# Altium schematic sheet PROGRAMMER.SchDoc
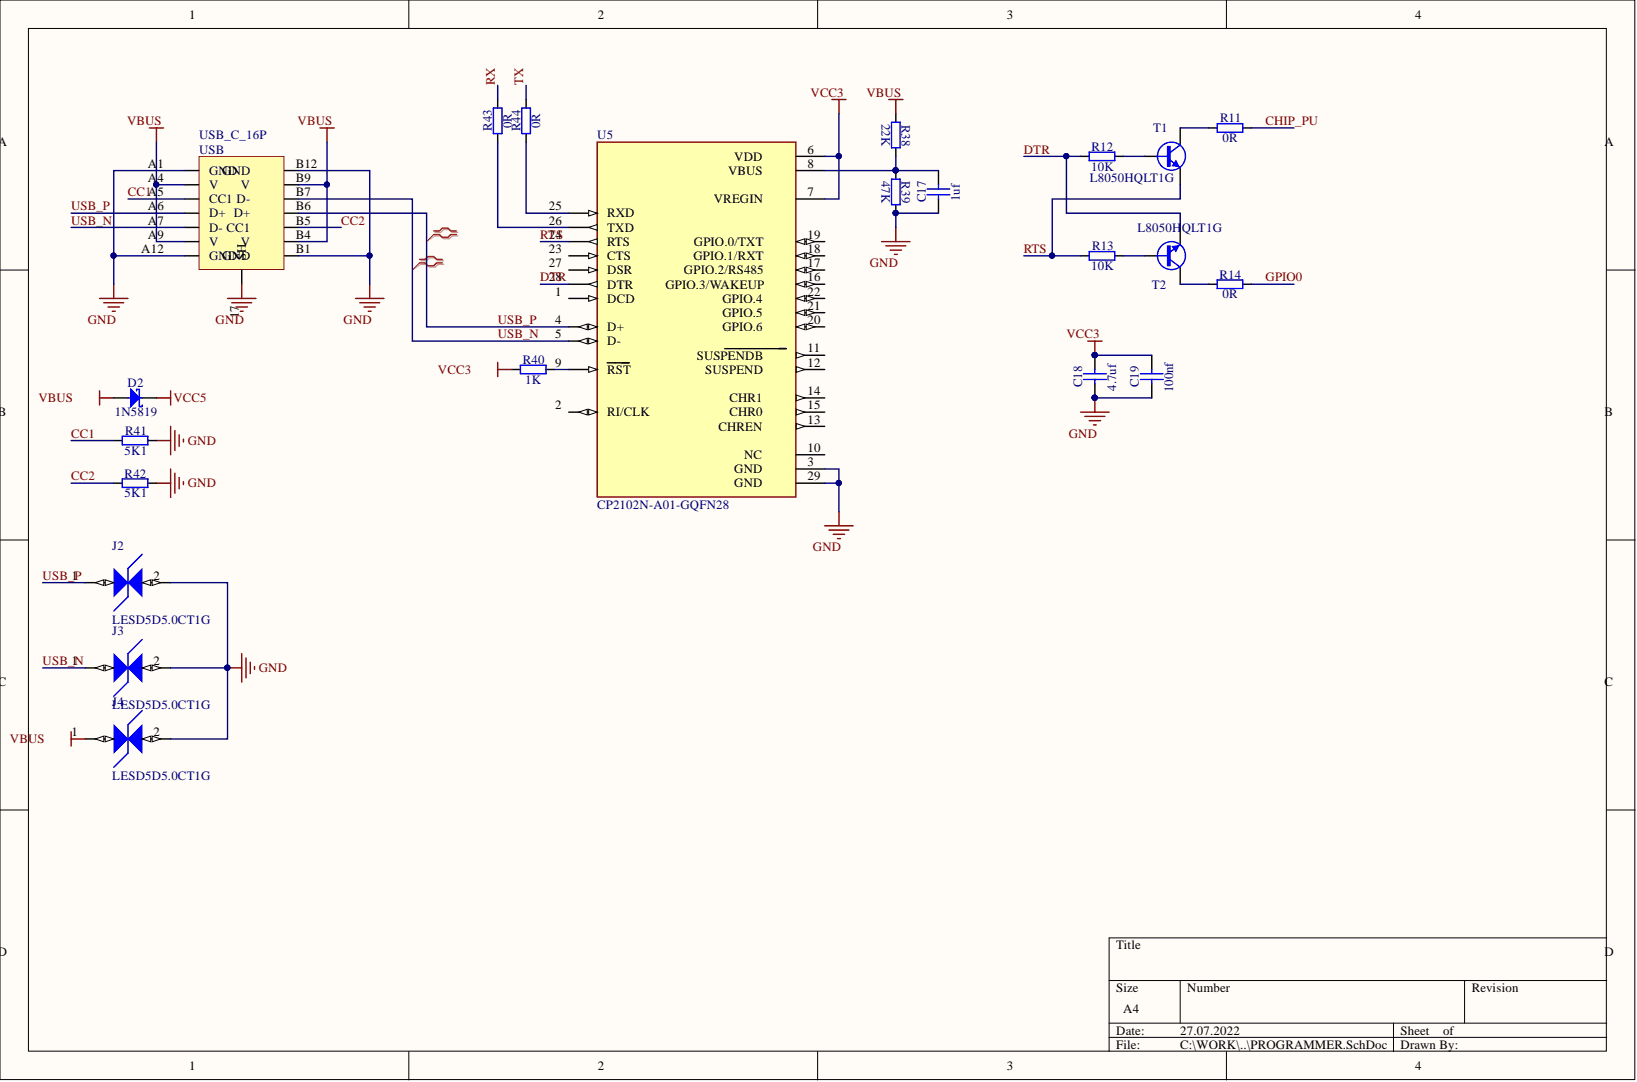
[diagram p0: PROGRAMMER.SchDoc]

## Parts
C17  1uf  [CAP_0603]
C18  4.7uf  [CAP_0805]
C19  100nf  [CAP_0603]
D2  1N5819  [SOD2713X115N]
J2  LESD5D5.0CT1G  [LESD5D5.0CT1G]
J3  LESD5D5.0CT1G  [LESD5D5.0CT1G]
J4  LESD5D5.0CT1G  [LESD5D5.0CT1G]
R11  0R  [RES_0603]
R12  10K  [RES_0603]
R13  10K  [RES_0603]
R14  0R  [RES_0603]
R38  22K  [RES_0603]
R39  47K  [RES_0603]
R40  1K  [RES_0603]
R41  5K1  [RES_0603]
R42  5K1  [RES_0603]
R43  0R  [RES_0603]
R44  0R  [RES_0603]
T1  L8050HQLT1G  [SOT95P240X110-3N]
T2  L8050HQLT1G  [SOT95P240X110-3N]
U5  CP2102N-A01-GQFN28  [QFN50P500X500X80-29N]
USB  USB_C_16P  [MC-TYF-J35-1635B]

## Nets
GND: C17.1 C18.1 C19.1 J2.2 J3.2 J4.2 R39.2 R41.2 R42.2 U5.3 U5.29 USB.17(SH) USB.A1(GND) USB.A12(GND) USB.B1 USB.B12
VBUS: D2.2 J4.1 R38.1 USB.A4(V) USB.A9(V) USB.B4 USB.B9
VCC3: C18.2 C19.2 R40.1 U5.6 U5.7
VCC5: D2.1
CC1: R41.1 USB.A5
CC2: R42.1 USB.B5
CHIP_PU: R11.2
DTR: R12.1 T2.2 U5.28(DTR)
GPIO0: R14.2
RTS: R13.1 T1.2 U5.24(RTS)
RX: R43.2
TX: R44.2
USB_N: J3.1 U5.5(D-) USB.A7(D-_CC1) USB.B7
USB_P: J2.1 U5.4(D+) USB.A6(D+) USB.B6
NetC17_2: C17.2 R38.2 R39.1 U5.8
NetR11_1: R11.1 T1.3
NetR12_2: R12.2 T1.1
NetR13_2: R13.2 T2.1
NetR14_1: R14.1 T2.3
NetR40_2: R40.2 U5.9(RST)
NetR43_1: R43.1 U5.26(TXD)
NetR44_1: R44.1 U5.25(RXD)

Not connected: U5 1(DCD) 2(RI/CLK) 10 11 12 13 14 15 16 17 18 19 20 21 22 23(CTS) 27(DSR)

## Library data of the parts
C17 = C0603_1UF: Non-polarised capacitor; Database Table Name: CAP; Voltage: 16V; Код: 40; Case: 0603; Component Kind: Standard; Part Number: C0603_1UF; Pin Count: 2; Value: 1uf; Library Name: MIPS_COMPONENTS_LIB.DbLib
C18 = C0805_4UF7: Non-polarised capacitor; Database Table Name: CAP; GoodsBriefName: RC_C_0805_4UF7_CC0805KKX5R7BB475_1PCS; GoodsID: 59207; Voltage: 16V; Код: 7; Case: 0805; Component Kind: Standard; Part Number: C0805_4UF7; Pin Count: 2; Value: 4.7uf; Library Name: MIPS_COMPONENTS_LIB.DbLib
C19 = C0603_100NF: Non-polarised capacitor; Database Table Name: CAP; GoodsBriefName: RC_C_0603_100NF_1PCS; GoodsID: 47802; Voltage: 50V; Код: 2; Case: 0603; Component Kind: Standard; Part Number: C0603_100NF; Pin Count: 2; Value: 100nf; Library Name: MIPS_COMPONENTS_LIB.DbLib
D2 = D_5819_SOD323: Schottky Diode; Database Table Name: D; GoodsBriefName: RC_D_1N5819_SOD323; GoodsID: 52854; Код: 5; Case: sod-323; Component Kind: Standard; Part Number: D_5819_SOD323; Pin Count: 2; Value: 1N5819; Library Name: MIPS_COMPONENTS_LIB.DbLib
J2-J4 = D_LESD5D5.0CT1G: Transient Voltage Suppressors for ESD Protection; Database Table Name: D; MF: Leshan Radio Co.; Код: 12; Availability: Not in stock; Case: SOD523; Check_prices: https://www.snapeda.com/parts/LESD5D5.0CT1G/Leshan%20Radio%20Co./view-part/4550964/?ref=eda; Component Kind: Standard; MP: LESD5D5.0CT1G; Package: None; Part Number: D_LESD5D5.0CT1G; Pin Count: 2; Price: None; SnapEDA_Link: https://www.snapeda.com/parts/LESD5D5.0CT1G/Leshan%20Radio%20Co./view-part/4550964/?ref=snap; Value: LESD5D5.0CT1G; Library Name: MIPS_COMPONENTS_LIB.DbLib
R11 R14 R43 R44 = R0603_0R_1%: Resistor; Database Table Name: res; GoodsBriefName: RC_R_0603_0OM_1PCS; GoodsID: 59839; Код: 7; Case: 0603; Component Kind: Standard; Part Number: R0603_0R_1%; Pin Count: 2; Value: 0R; Library Name: MIPS_COMPONENTS_LIB.DbLib
R12 R13 = R0603_10K_1%: Resistor; Database Table Name: res; GoodsBriefName: RC_R_0603_10KOM_1PERCENT_1PCS; GoodsID: 59406; Код: 10; Case: 0603; Component Kind: Standard; Part Number: R0603_10K_1%; Pin Count: 2; Value: 10K; Library Name: MIPS_COMPONENTS_LIB.DbLib
R38 = R0603_22K1_5%: Resistor; Database Table Name: res; Код: 55; Case: 0603; Component Kind: Standard; Part Number: R0603_22K1_5%; Pin Count: 2; Value: 22K; Library Name: MIPS_COMPONENTS_LIB.DbLib
R39 = R0603_47K5_5%: Resistor; Database Table Name: res; Код: 56; Case: 0603; Component Kind: Standard; Part Number: R0603_47K5_5%; Pin Count: 2; Value: 47K; Library Name: MIPS_COMPONENTS_LIB.DbLib
R40 = R0603_1K_1%: Resistor; Database Table Name: res; GoodsBriefName: RC_R_0603_1KOM_1PERCENT_1PCS; GoodsID: 59407; Код: 8; Case: 0603; Component Kind: Standard; Part Number: R0603_1K_1%; Pin Count: 2; Value: 1K; Library Name: MIPS_COMPONENTS_LIB.DbLib
R41 R42 = R0603_5K1_1%: Resistor; Database Table Name: res; GoodsBriefName: RC_R_0603_5-1KOM_5PERCENT; GoodsID: 47801; Код: 9; Case: 0603; Component Kind: Standard; Part Number: R0603_5K1_1%; Pin Count: 2; Value: 5K1; Library Name: MIPS_COMPONENTS_LIB.DbLib
T1 T2 = TR_L8050: NPN Transistor; Database Table Name: TR; Код: 6; Case: SOT23; Component Kind: Standard; Part Number: TR_L8050; Pin Count: 3; Value: L8050HQLT1G; Library Name: MIPS_COMPONENTS_LIB.DbLib
U5 = IC_CP1202N: USB Bridge, USB to UART USB 2.0 UART Interface 28-QFN (5x5); Database Table Name: IC; MF: Silicon Labs; Value: CP2102N-A02; Код: 36; Availability: Bad; Case: QFN28; Check_prices: https://www.snapeda.com/parts/CP2102N-A01-GQFN28/Silicon%20Labs/view-part/554799/?ref=eda; Component Kind: Standard; MP: CP2102N-A01-GQFN28; Package: QFN-28 Silicon Labs; Part Number: IC_CP1202N; Pin Count: 29; Price: None; SnapEDA_Link: https://www.snapeda.com/parts/CP2102N-A01-GQFN28/Silicon%20Labs/view-part/554799/?ref=snap; Library Name: MIPS_COMPONENTS_LIB.DbLib
USB = CONN_USB-C_MC-TYF-J35-1635B: Database Table Name: CONN; Value: MC-TYF-J79-1616A; Код: 35; Component Kind: Standard; Part Number: CONN_USB-C_MC-TYF-J35-1635B; Pin Count: 20; Library Name: MIPS_COMPONENTS_LIB.DbLib
